# Altium schematic sheet PORT.SchDoc
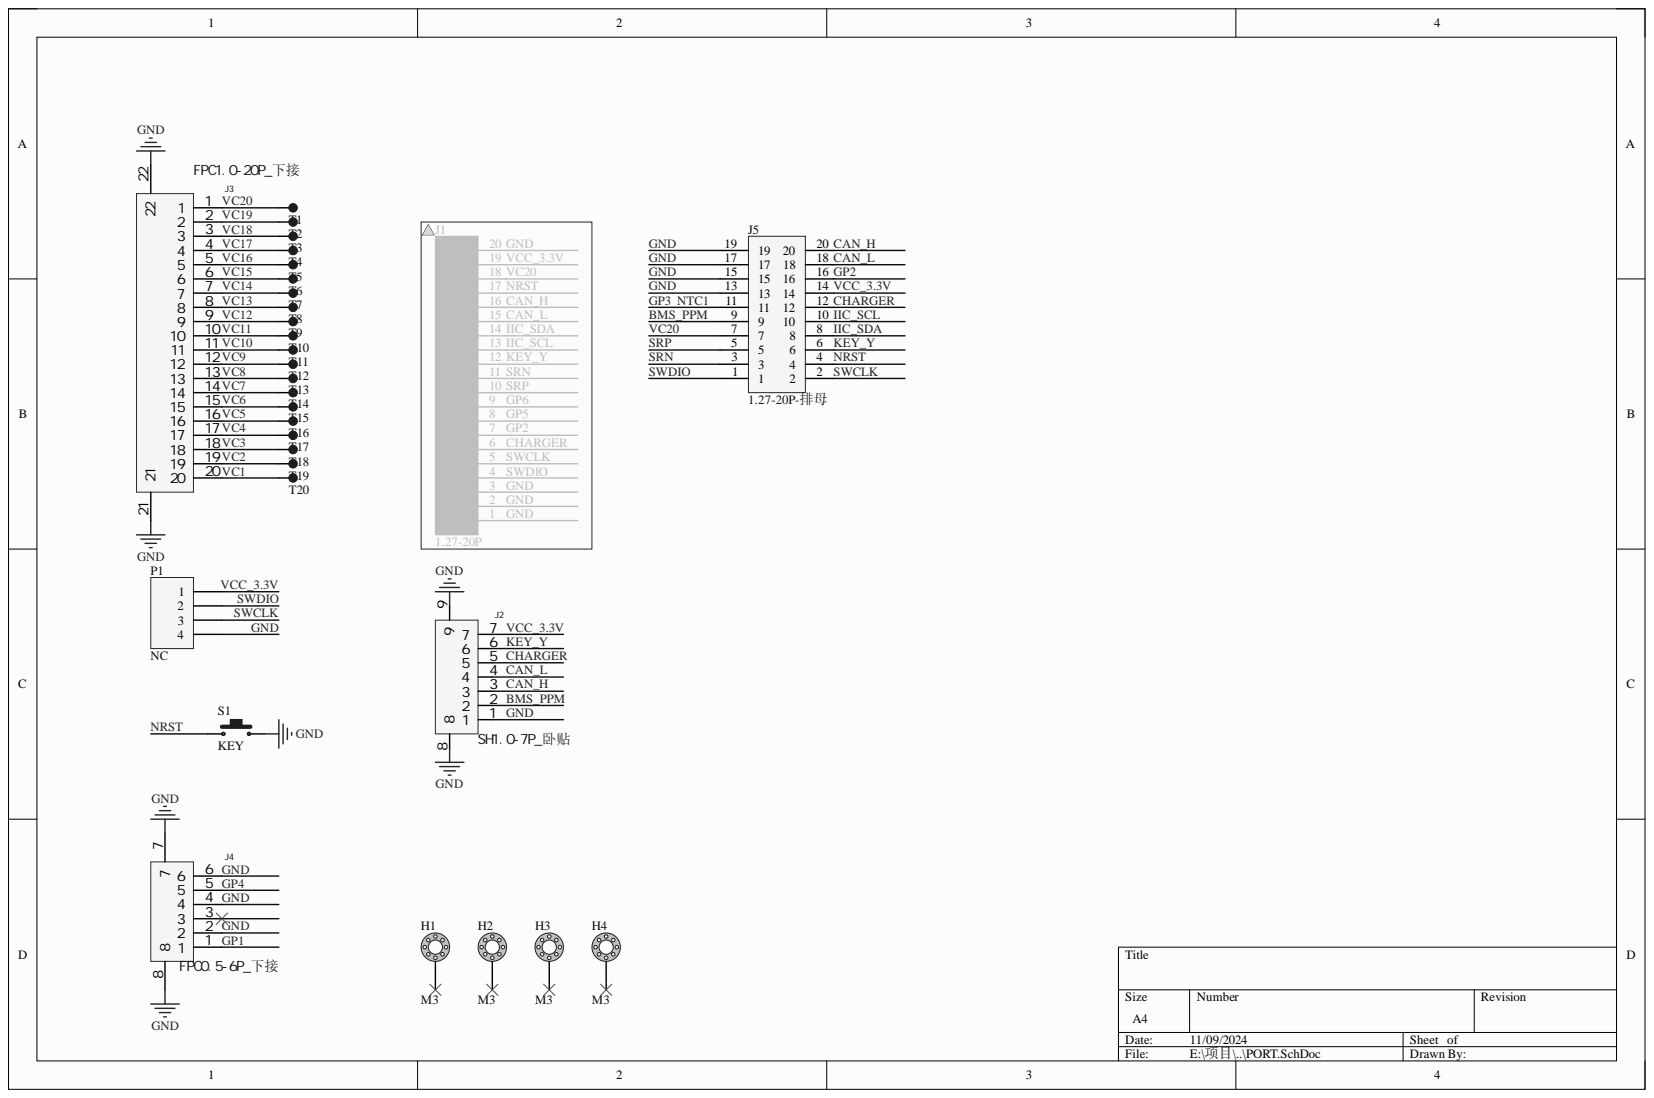
[diagram p0: PORT.SchDoc]

## Parts
H1  M3  [M3]
H2  M3  [M3]
H3  M3  [M3]
H4  M3  [M3]
J2  SH1.0-7P_卧贴  [CONN-SMD_7P-P1.00_SHOU-HAN_1.0-7P-WT]
J3  FPC1.0-20P_下接  [FPC1.0-20P-抽拉式-下接]
J4  FPC0.5-6P_下接  [FPC0.5-6P-抽拉式-下接]
J5  1.27-20P-排母  [CONN-TH_20P-P1.27_KINGHELM_KH-1.27FH-2X10P-H4.3]
P1  NC  [HDR1X4]
S1  KEY  [SW-SMD_L4.0-W2.9-LS5.0]
T1  T  [T_1.5mm]
T2  T  [T_1.5mm]
T3  T  [T_1.5mm]
T4  T  [T_1.5mm]
T5  T  [T_1.5mm]
T6  T  [T_1.5mm]
T7  T  [T_1.5mm]
T8  T  [T_1.5mm]
T9  T  [T_1.5mm]
T10  T  [T_1.5mm]
T11  T  [T_1.5mm]
T12  T  [T_1.5mm]
T13  T  [T_1.5mm]
T14  T  [T_1.5mm]
T15  T  [T_1.5mm]
T16  T  [T_1.5mm]
T17  T  [T_1.5mm]
T18  T  [T_1.5mm]
T19  T  [T_1.5mm]
T20  T  [T_1.5mm]

## Nets
BMS_PPM: J2.2 J5.9
CAN_H: J2.3 J5.20
CAN_L: J2.4 J5.18
CHARGER: J2.5 J5.12
GND: J2.1 J2.8 J2.9 J3.21 J3.22 J4.2 J4.4 J4.6 J4.7 J4.8 J5.13 J5.15 J5.17 J5.19 P1.4 S1.2
GP1: J4.1
GP2: J5.16
GP3: J5.11
GP4: J4.5
IIC_SCL: J5.10
IIC_SDA: J5.8
KEY_Y: J2.6 J5.6
NRST: J5.4 S1.1
SRN: J5.3
SRP: J5.5
SWCLK: J5.2 P1.3
SWDIO: J5.1 P1.2
VC1: J3.20 T20.1
VC2: J3.19 T19.1
VC3: J3.18 T18.1
VC4: J3.17 T17.1
VC5: J3.16 T16.1
VC6: J3.15 T15.1
VC7: J3.14 T14.1
VC8: J3.13 T13.1
VC9: J3.12 T12.1
VC10: J3.11 T11.1
VC11: J3.10 T10.1
VC12: J3.9 T9.1
VC13: J3.8 T8.1
VC14: J3.7 T7.1
VC15: J3.6 T6.1
VC16: J3.5 T5.1
VC17: J3.4 T4.1
VC18: J3.3 T3.1
VC19: J3.2 T2.1
VC20: J3.1 J5.7 T1.1
VCC_3.3V: J2.7 J5.14 P1.1

Not connected: H1 1; H2 1; H3 1; H4 1; J4 3

## Library data of the parts
H1-H4 = HOLD: Component Kind: Standard; Library Name: UP-ROBOT Devices.SchLib
J2 = SH1.0-7P: Manufacturer: JST; Add into BOM: yes; Current Rating (Max): 1A; Device: SM07B-GHS-TB(LF)(SN); Number of PINs Per Row: 7; Pins Structure: 1x7P; Pitch: 1.25mm; Reference Series: GH; Component Kind: Standard; Contact Material: 磷青铜; Contact Plating: 锡; Convert to PCB: yes; Datasheet: https://atta.szlcsc.com/upload/public/pdf/source/20200407/C495552_6029EB70946590F27D235F661EA3D952.pdf; JLCPCB Part Class: Extended Part; LCSC Part Name: 1x7P 间距:1.25mm 卧贴 系列:GH; Library Name: BMS_20S_DVC1124.SchLib; Manufacturer Part: SM07B-GHS-TB(LF)(SN); Mounting Style: 卧贴; Number of Pins: 7P; Number of Rows: 1; Operating Temperature Range: -25℃~+85℃; Row Spacing: -; Supplier: LCSC; Supplier Footprint: SMD,P=1.25mm,卧贴; Supplier Part: C495552; Symbol: SM07B-GHS-TB(LF)(SN)
J3 = FPC1.0-20P_下接: Manufacturer: JS(钜硕电子); Add into BOM: yes; Device: AFA01-S20FCC-00; FFC, FCB Thickness: 0.3mm; Locking Feature: 翻盖式; Number of Contacts: 20P; Pitch: 1mm; Component Kind: Standard; Contact Type: 下接; Convert to PCB: yes; Datasheet: https://atta.szlcsc.com/upload/public/pdf/source/20180820/C262762_EBECD0847A529CA64FD00527F6604CA9.pdf; Height Above Board: 2mm; JLCPCB Part Class: Extended Part; LCSC Part Name: 间距:1mm P数:20P 翻盖式 下接; Library Name: BMS_20S_DVC1124.SchLib; Manufacturer Part: AFA01-S20FCC-00; Mounting Type: 卧贴; Supplier: LCSC; Supplier Footprint: SMD,P=1mm,卧贴; Supplier Part: C262389; Symbol: AFA01-S20FCC-00
J4 = FPC0.5-6P_下接: Manufacturer: XUNPU(讯普); Add into BOM: yes; Device: FPC-05F-6PH20; FFC, FCB Thickness: 0.3mm; Locking Feature: 翻盖式; Number of Contacts: 6P; Pitch: 0.5mm; Component Kind: Standard; Contact Type: 下接; Convert to PCB: yes; Datasheet: https://atta.szlcsc.com/upload/public/pdf/source/20210922/16B003FB53336DDF6538142CC465AC4D.pdf; Height Above Board: 2mm; JLCPCB Part Class: Extended Part; LCSC Part Name: 间距:0.5mm P数:6P 翻盖式 下接; Library Name: BMS_20S_DVC1124.SchLib; Manufacturer Part: FPC-05F-6PH20; Mounting Type: 卧贴; Operating Temperature Range: -25℃~+85℃; Supplier: LCSC; Supplier Footprint: SMD,P=0.5mm,卧贴; Supplier Part: C2856796; Symbol: FPC-05F-6PH20
J5 = Pin HDR2X10: Component Kind: Standard; Library Name: 排针.SchLib
P1 = Header 4: Component Kind: Standard; LatestRevisionDate: 17-Jul-2002; LatestRevisionNote: Re-released for DXP Platform.; Signal Integrity: Connector; Library Name: 智行SE_Test_Tooling.SCHLIB; Publisher: Altium Limited
S1 = SW: TS-KG88C-ART25F; Component Kind: Standard; Library Name: UP-ROBOT Devices.SchLib
T1-T20 = TestPad: Component Kind: Standard; Library Name: ChassisCon.SCHLIB
